# Altium schematic sheet Circuit overview.SchDoc("Circuit overview")
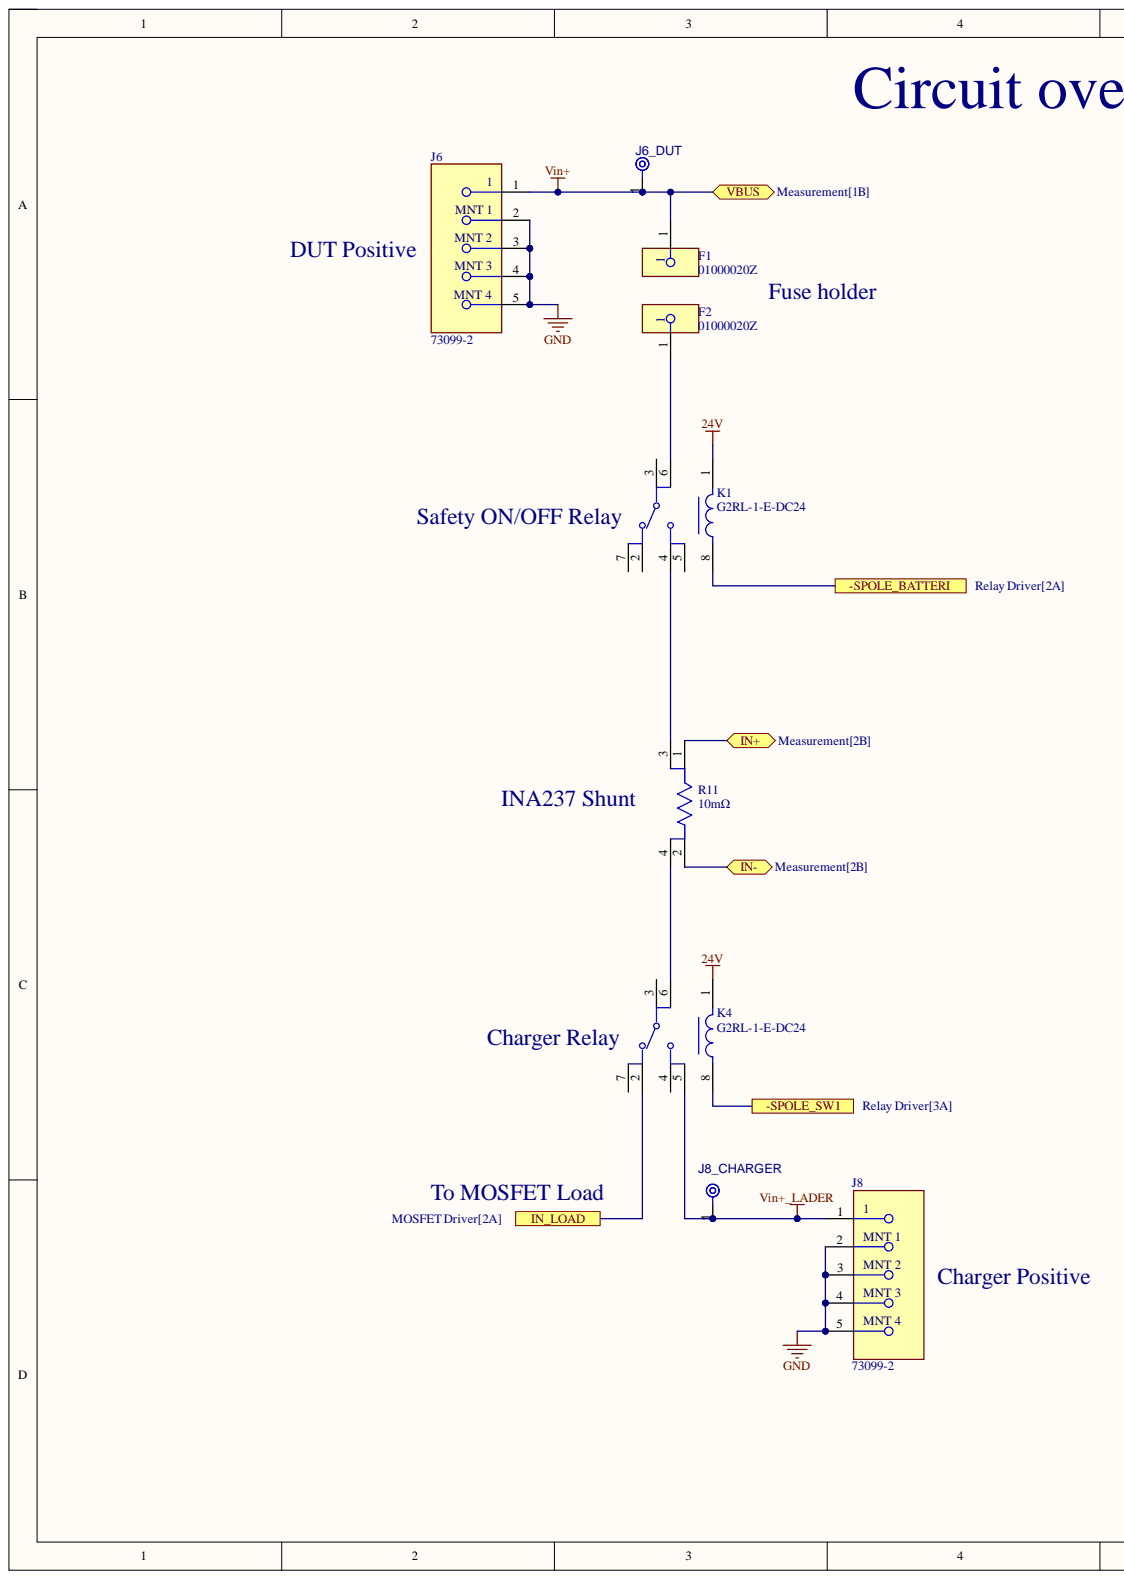
[diagram p0: Circuit overview.SchDoc, left]
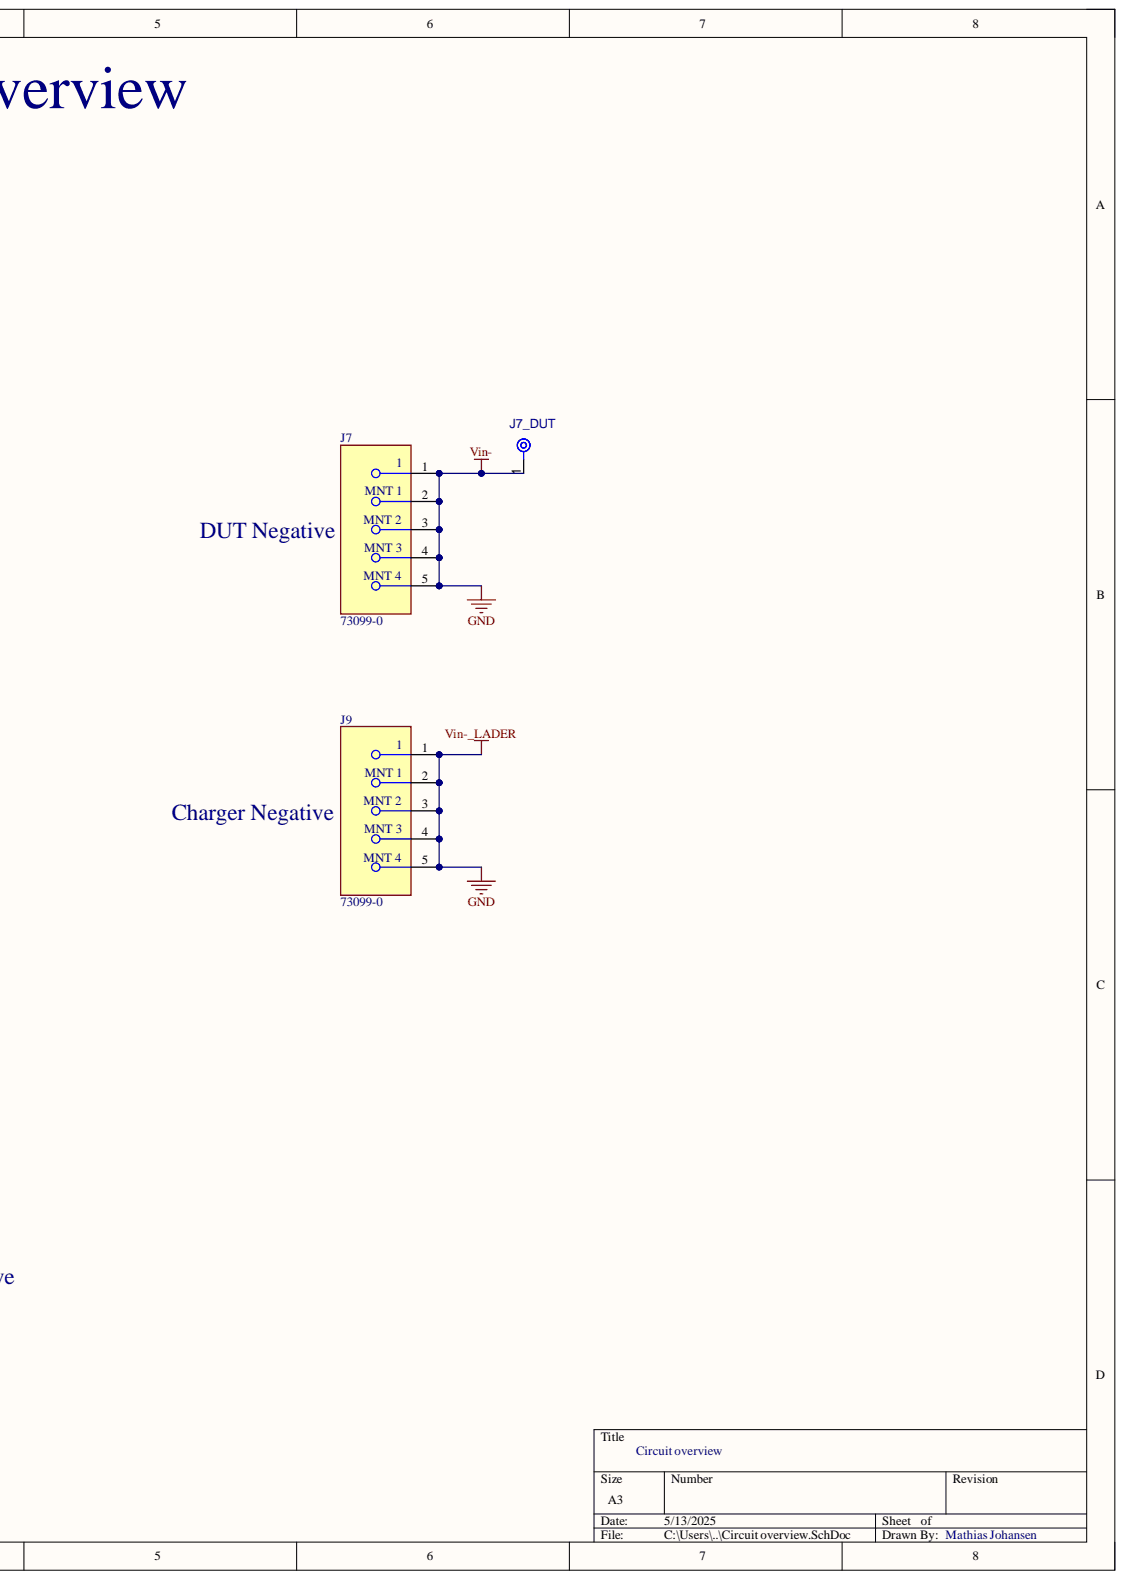
[diagram p1: Circuit overview.SchDoc, right]

## Parts
F1  01000020Z  [FP-0100-MFG]
F2  01000020Z  [FP-0100-MFG]
J6  73099-2  [FP-73099-2-MFG]
J6_DUT  TPAD6R  [TP06R]  (value not drawn)  (no BOM)
J7  73099-0  [FP-73099-0-MFG]
J7_DUT  TPAD6R  [TP06R]  (value not drawn)  (no BOM)
J8  73099-2  [FP-73099-2-MFG]
J8_CHARGER  TPAD6R  [TP06R]  (value not drawn)  (no BOM)
J9  73099-0  [FP-73099-0-MFG]
K1  G2RL-1-E-DC24  Coil Voltage (DC): 24V  [FP-G2RL-1-E-DC24-MFG]
K4  G2RL-1-E-DC24  Coil Voltage (DC): 24V  [FP-G2RL-1-E-DC24-MFG]
R11  value 10mΩ  Resistance: 10mΩ  [FP-CSM3637-0R002-0R2-MFG]  (hidden comment: Y14880R01000B9R)

## Ports
-SPOLE_BATTERI, -SPOLE_SW1, IN+, IN-, IN_LOAD, VBUS

## Nets
24V: K1.1 K4.1
GND: J6.2 J6.3 J6.4 J6.5 J7.1 J7.2 J7.3 J7.4 J7.5 J7_DUT.1 J8.2 J8.3 J8.4 J8.5 J9.1 J9.2 J9.3 J9.4 J9.5
Vin+_LADER: J8.1 J8_CHARGER.1 K4.5
-SPOLE_BATTERI: K1.8 ; port -SPOLE_BATTERI
-SPOLE_SW1: K4.8 ; port -SPOLE_SW1
IN_LOAD: K4.2 ; port IN_LOAD
Vin+: F1.1 J6.1 J6_DUT.1 ; port VBUS
NetF2_1: F2.1 K1.6
NetK1_4: K1.4 R11.3
NetK4_6: K4.6 R11.4
NetR11_1: R11.1
NetR11_2: R11.2

Not connected: K1 2 3 5 7; K4 3 4 7

## Library data of the parts
F1 F2 = CMP-08604-000025-1: FUSE CLIP CARTRIDGE 600V 20A PCB; Body Material: Bronze; Number of Circuits: 1; Voltage Rating: 250V; Component Kind: Standard; Contact Material: Bronze; Contact Plating: Tin; Current Rating: 20A; Depth: 5.9mm; Length: 4.1mm; Library Name: Altium Content Vault; Mount: PCB; Orientation: Horizontal; Package Quantity: 1000; Packaging: Bulk; Termination: PC Pin; Voltage: 600V
J6 J8 = CMP-73906-000005-1: R/A 4MM MOUNTG SAFE JACK/PCB RED; Component Kind: Standard; Library Name: Altium Content Vault
J6_DUT J7_DUT J8_CHARGER = CMP-00028-00002-2: 0.6mm round; Pins: 1; Library Name: Sondre Pettersen
J7 J9 = CMP-73906-000007-1: R/A 4MM MOUNTG SAFE JACK/PCB BLK; Component Kind: Standard; Library Name: Altium Content Vault
K1 K4 = CMP-08822-000079-1: RELAY GEN PURPOSE SPDT 16A 24V; Application(s): POWER; Coil Current: 16.7mA; Coil Power: 400mW; Coil Resistance: 1.44kR; Coil Type: Non-Latching; Life (Hours): 30000Hour; Max Voltage Rating (AC): 440V; Max Voltage Rating (DC): 300V; Operate Time: 15ms; Relay Type: General Purpose; Release Time: 5ms; Case/Package: DIP; Component Kind: Standard; Contact Current Rating: 16A; Contact Material: Silver; Depth: 12.7mm; Height: 15.7mm; Lead Free: Lead Free; Length: 29mm; Library Name: Altium Content Vault; Max Current Rating: 16A; Max Operating Temperature: 85°C; Min Operating Temperature: -40°C; Mount: Through Hole; Number of Pins: 8; Package Quantity: 20; Packaging: Rail/Tube; Power Consumption: 400mW; Radiation Hardening: No; Series: G2RL; Termination: PC Pin; Throw Configuration: SPDT; Voltage Rating (AC): 440V; Weight: 12g; Width: 12.7mm
R11 = CMP-10849-000002-1: RES 0.01 OHM 0.1% 3W 3637; Manufacturer: Vishay Precision Group; Temperature Coefficient: 15ppm/°C; Automotive: No; Category: Res; Component Kind: Standard; ComponentLink2Description: Datasheet; ComponentLink2URL: http://www.vishaypg.com/docs/63089/csm.pdf; Composition: Metal Foil; Device Class L1: Passive Components; Device Class L2: Resistors; Device Class L3: Chip SMD Resistors; Digikey Description: RES 0.01 OHM 0.1% 3W 3637; Height: 0.889mm; Lead Free: Yes; Library Name: Altium Content Vault; Manufacturer Part Number: Y14880R01000B9R; Military Standard: true; Octopart: https://octopart.com/y14880r01000b9r-vishay+precision+group-29797487; Package: CSM3637-0R002-0R2; Power Rating: 3W; RoHS: TRUE; Series: CSM; Temperature: -65°C to +170°C; Temperature Range High: +170°C; Temperature Range Low: -65°C; Tolerance: 0.1%
